# Altium schematic sheet Radio.SchDoc
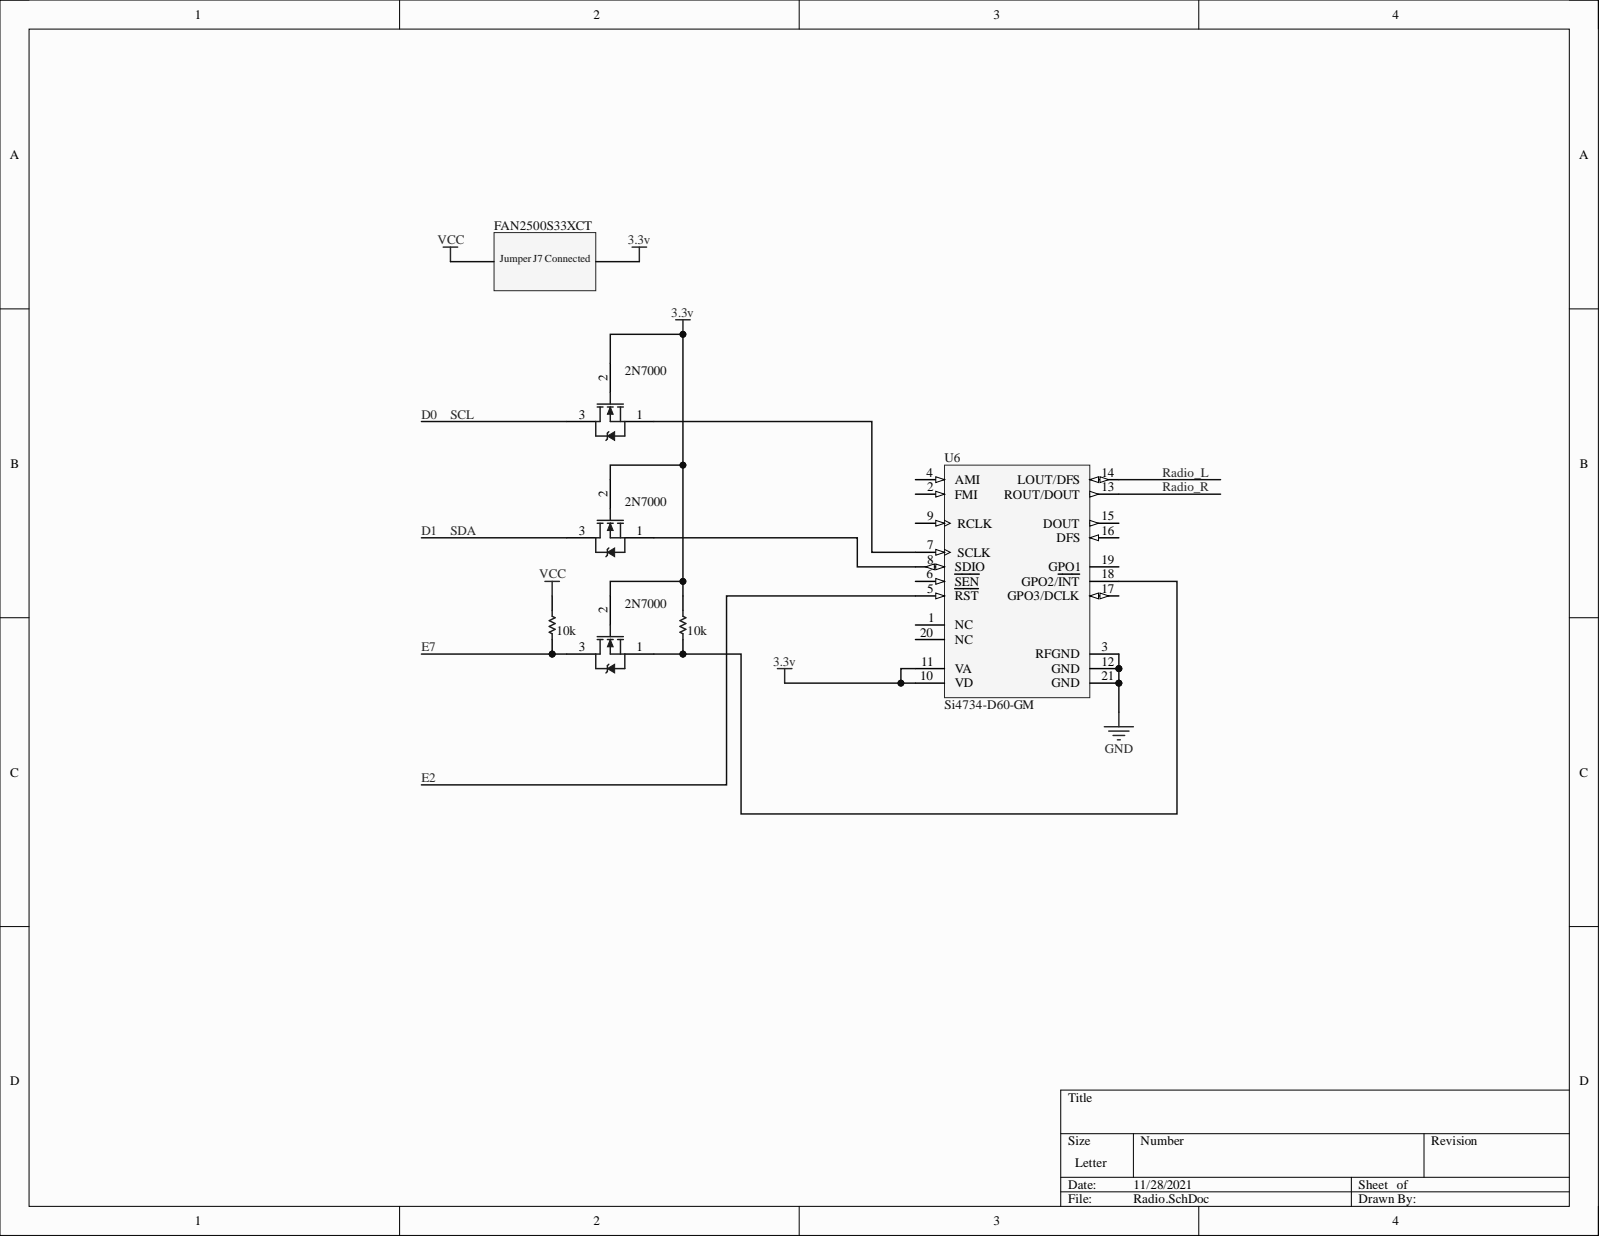
[diagram p0: Radio.SchDoc]

## Parts
1  2N7000  [TI-LP3]
2  2N7000  [TI-LP3]
3  2N7000  [TI-LP3]
R1  10k  [PCB-q466dezs08uszq8774n0-1]
R1  10k  [PCB-q466dezs08uszq8774n0-1]
U6  Si4734-D60-GM  [SLAB-QFN-20-1700x1700TP_V]

## Nets
3.3v: 1.2 2.2 3.2 R1.2 U6.10(VD) U6.11(VA)
GND: U6.3(RFGND) U6.12(GND) U6.21(GND)
VCC: R1.2
D0: 1.3
D1: 2.3
E2: U6.5(RST)
E7: 3.3 R1.1
Radio_L: U6.14(LOUT/DFS)
Radio_R: U6.13(ROUT/DOUT)
Net1_1: 1.1 U6.7(SCLK)
Net2_1: 2.1 U6.8(SDIO)
Net3_1: 3.1 R1.1 U6.18(GPO2/INT)

Not connected: U6 1(NC) 2(FMI) 4(AMI) 6(SEN) 9(RCLK) 15(DOUT) 16(DFS) 17(GPO3/DCLK) 19(GPO1) 20(NC)

## Library data of the parts
1-3 = CMP-dae013efe35c0527-4: N-Channel 60 V 5 Ohm Through Hole Advanced Small Signal Mosfet - TO-92; Manufacturer Part Number: 2N7000BU; Breakdown Voltage (Drain to Source): 60.0 V; Breakdown Voltage [Gate to Source]: -20.0 V to 20.0 V; Continuous Drain Current (Ids): 200 mA; Drain to Source Resistance (on) (Rds): 5.00 Ω; Lead-Free Status: Lead Free; Power Dissipation: 400 mW; Case/Package: TO-92; Component Kind: Standard; Current Rating: 200 mA; Drain to Source Voltage (Vds): 60.0 V; Library Name: My Personal Space; Lifecycle Status: Active; Mounting Style: Through Hole; Number of Pins: 3; Octopart URL: http://octopart.com/2n7000bu-fairchild+semiconductor-335066; OctopartID: dae013efe35c0527; Packaging: Bulk; Polarity: N-Channel; REACH SVHC Compliance: No SVHC; RoHS: Compliant; Voltage Rating (DC): 60.0 V
R1 R1 = CMP-e82f23a0358237e8-1: RC Series 0201 0.05 W 1 kOhms 5% ±200 ppm/°C SMT Thick Film Chip Resistor; Manufacturer Part Number: RC0201JR-071KL; Lead-Free Status: Lead Free; Power Rating: 50.0 mW; Resistance: 1.00 kΩ; Voltage Rating (DC): 25.0 V; Case/Package: 0201; Component Kind: Standard; Library Name: My Personal Space; Mounting Style: Surface Mount; Number of Pins: 2; Octopart URL: http://octopart.com/rc0201jr-071kl-yageo-42334411; OctopartID: e82f23a0358237e8; Packaging: Tape & Reel; REACH SVHC Compliance: No SVHC; Resistance Tolerance: ±5%; RoHS: Compliant; Size-Length: 600 µm
U6 = CMP-2112143-2: Broadcast AM / FM / SW / LW Radio Receiver, -20 to 85 degC, 20-pin QFN, Tray; Component Kind: Standard; Library Name: My Personal Space; Manufacturer Part Number: SI4734-D60-GM; Octopart Url: https://octopart.com/si4734-d60-gm-silicon+labs-19668706; OctopartID: b78c7faa8c08684b
